# Altium schematic sheet 1.1.1_Interface_board_MCU.SchDoc
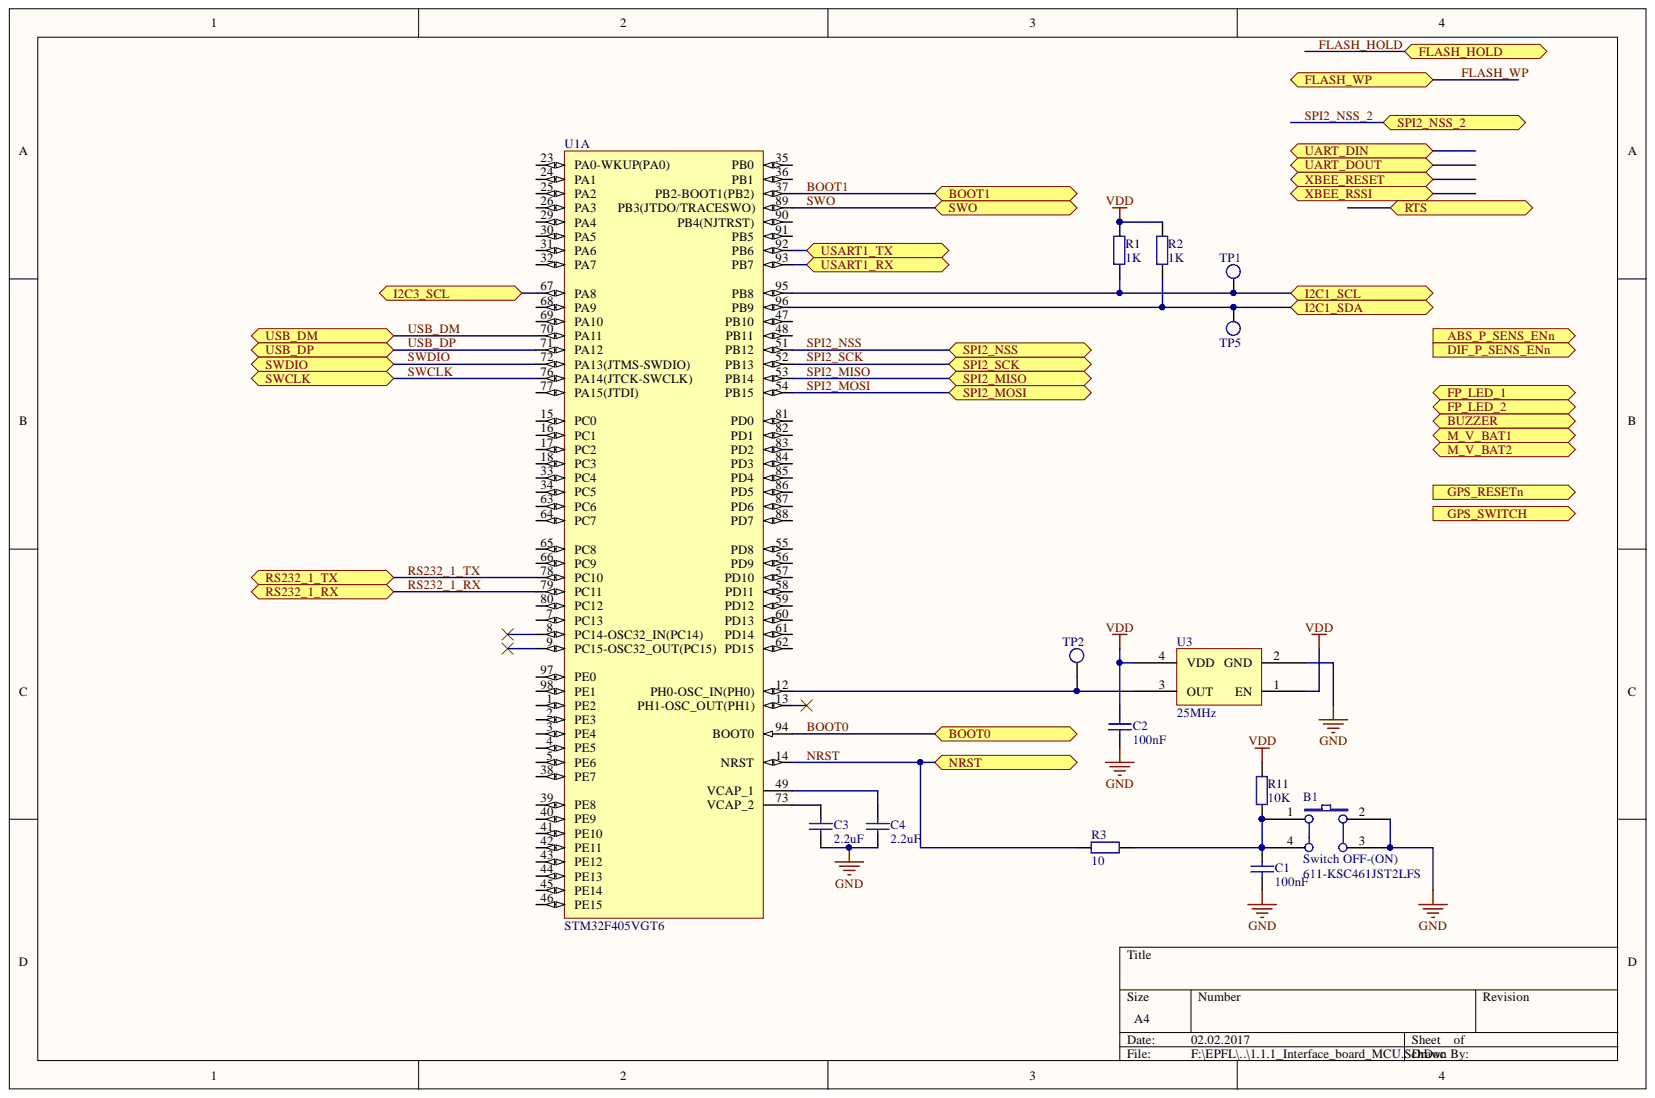
[diagram p0: 1.1.1_Interface_board_MCU.SchDoc]

## Parts
B1  Switch OFF-(ON)  Supplier Part Number 1: 611-KSC461JST2LFS  [Switch CK]
C1  100nF  [CAP0603R]
C2  100nF  [CAP0603R]
C3  2.2uF  [CAP1206W]
C4  2.2uF  [CAP1206W]
R1  1K  [0603]
R2  1K  [0603]
R3  10  [0603]
R11  10K  [0603]
TP1  Test point  [TP]  (value not drawn)
TP2  Test point  [TP]  (value not drawn)
TP5  Test point  [TP]  (value not drawn)
U1  STM32F405VGT6  [STM-LQFP100_N]  (part A)
U3  25MHz  [SMD_3.2X2.5MM_4P]

## Ports
ABS_P_SENS_ENN, BOOT0, BOOT1, BUZZER, DIF_P_SENS_ENN, FLASH_HOLD, FLASH_WP, FP_LED_1, FP_LED_2, GPS_RESETN, GPS_SWITCH, I2C1_SCL, I2C1_SDA, I2C3_SCL, M_V_BAT1, M_V_BAT2, NRST, RS232_1_RX, RS232_1_TX, RTS, SPI2_MISO, SPI2_MOSI, SPI2_NSS, SPI2_NSS_2, SPI2_SCK, SWCLK, SWDIO, SWO, UART_DIN, UART_DOUT, USART1_RX, USART1_TX, USB_DM, USB_DP, XBEE_RESET, XBEE_RSSI

## Nets
GND: B1.2 B1.3 C1.2 C2.1 C3.1 C4.1 U3.2(GND)
VDD: C2.2 R1.2 R2.2 R11.2 U3.1(EN) U3.4(VDD)
BOOT0: U1.94(BOOT0) ; port BOOT0
BOOT1: U1.37(PB2-BOOT1(PB2)) ; port BOOT1
I2C1_SCL: R1.1 TP1.1 U1.95(PB8) ; port I2C1_SCL
I2C1_SDA: R2.1 TP5.1 U1.96(PB9) ; port I2C1_SDA
I2C3_SCL: U1.67(PA8) ; port I2C3_SCL
NRST: R3.1 U1.14(NRST) ; port NRST
RS232_1_RX: U1.79(PC11) ; port RS232_1_RX
RS232_1_TX: U1.78(PC10) ; port RS232_1_TX
SPI2_MISO: U1.53(PB14) ; port SPI2_MISO
SPI2_MOSI: U1.54(PB15) ; port SPI2_MOSI
SPI2_NSS: U1.51(PB12) ; port SPI2_NSS
SPI2_SCK: U1.52(PB13) ; port SPI2_SCK
SWCLK: U1.76(PA14(JTCK-SWCLK)) ; port SWCLK
SWDIO: U1.72(PA13(JTMS-SWDIO)) ; port SWDIO
SWO: U1.89(PB3(JTDO/TRACESWO)) ; port SWO
USART1_RX: U1.93(PB7) ; port USART1_RX
USART1_TX: U1.92(PB6) ; port USART1_TX
USB_DM: U1.70(PA11) ; port USB_DM
USB_DP: U1.71(PA12) ; port USB_DP
NetB1_1: B1.1 B1.4 C1.1 R3.2 R11.1
NetC3_2: C3.2 U1.73(VCAP_2)
NetC4_2: C4.2 U1.49(VCAP_1)
NetTP2_1: TP2.1 U1.12(PH0-OSC_IN(PH0)) U3.3(OUT)
FLASH_HOLD: ; port FLASH_HOLD
FLASH_WP: ; port FLASH_WP
N00006: ; port DIF_P_SENS_ENN
N00007: ; port ABS_P_SENS_ENN
N00008: ; port M_V_BAT1
N00009: ; port GPS_RESETN
N00010: ; port GPS_SWITCH
N00011: ; port M_V_BAT2
N00012: ; port BUZZER
N00013: ; port FP_LED_2
N00014: ; port FP_LED_1
N00015: ; port RTS
N00016: ; port XBEE_RESET
N00017: ; port XBEE_RSSI
N00018: ; port UART_DOUT
N00019: ; port UART_DIN
SPI2_NSS_2: ; port SPI2_NSS_2

Not connected: U1 1(PE2) 2(PE3) 3(PE4) 4(PE5) 5(PE6) 7(PC13) 8(PC14-OSC32_IN(PC14)) 9(PC15-OSC32_OUT(PC15)) 13(PH1-OSC_OUT(PH1)) 15(PC0) 16(PC1) 17(PC2) 18(PC3) 23(PA0-WKUP(PA0)) 24(PA1) 25(PA2) 26(PA3) 29(PA4) 30(PA5) 31(PA6) 32(PA7) 33(PC4) 34(PC5) 35(PB0) 36(PB1) 38(PE7) 39(PE8) 40(PE9) 41(PE10) 42(PE11) 43(PE12) 44(PE13) 45(PE14) 46(PE15) 47(PB10) 48(PB11) 55(PD8) 56(PD9) 57(PD10) 58(PD11) 59(PD12) 60(PD13) 61(PD14) 62(PD15) 63(PC6) 64(PC7) 65(PC8) 66(PC9) 68(PA9) 69(PA10) 77(PA15(JTDI)) 80(PC12) 81(PD0) 82(PD1) 83(PD2) 84(PD3) 85(PD4) 86(PD5) 87(PD6) 88(PD7) 90(PB4(NJTRST)) 91(PB5) 97(PE0) 98(PE1)

## Library data of the parts
B1 = Switch OFF-(ON): OFF-(ON); Component Kind: Standard; Supplier 1: Mouser; Library Name: Rocket_library.SchLib
C1 C2 = C_100nF: Capacitor; Component Kind: Standard; Signal Integrity: Cap; Simulation: CAP; Supplier 1: Mouser; Supplier Part Number 1: 81-GCG188R91H104KA1D; Library Name: Rocket_library.SchLib
C3 C4 = C_2.2uF_1206: Capacitor; Component Kind: Standard; Signal Integrity: Cap; Simulation: CAP; Supplier 1: Mouser; Supplier Part Number 1: 81-GCG31MR71E225JA2L; Library Name: Rocket_library.SchLib
R1 R2 = R_0603_01W_1K: 0.1W 1K; Component Kind: Standard; Signal Integrity: Res; Simulation: RESISTOR; Supplier 1: Mouser; Supplier Part Number 1: 667-ERJ-3GEYJ102V; Library Name: Rocket_library.SchLib
R3 = R_0603_025W_10Ohm: 0.25W 10Ohm; Component Kind: Standard; Supplier 1: Mouser; Supplier Part Number 1: 667-ERJ-PA3J100V; Library Name: Rocket_library.SchLib
R11 = R_0603_01W_10K: 0.1W 10K; Component Kind: Standard; Signal Integrity: Res; Simulation: RESISTOR; Supplier 1: Mouser; Supplier Part Number 1: 667-ERJ-3GEYJ103V; Library Name: Rocket_library.SchLib
U1 = STM32F405VGT6: ARM Cortex-M4 32-bit MCU+FPU, 210 DMIPS, 1024 kB Flash, 192 kB Internal RAM, 82 I/Os, 100-pin LQFP, -40 to 85 degC, Tray; Manufacturer: STMicroelectronics; D/A Converter: 2x12-bit; FLASH Size (Prog) (kB): 1024; I/Os (High Current): 82; Internal RAM Size (kB): 192; Operating Frequency(F) (Processor speed) (MHz): 168; Supply Voltage(Vcc) max (V): 3.6; Supply Voltage(Vcc) min (V): 1.8; Component Kind: Standard; ComponentLink1Description: Manufacturer URL; ComponentLink1URL: http://www.st.com/web/en/home.html; ComponentLink2Description: Datasheet; ComponentLink2URL: http://www.st.com/st-web-ui/static/active/en/resource/technical/document/datasheet/DM00037051.pdf; ComponentLink3Description: Package Specification; ComponentLink3URL: http://www.st.com/st-web-ui/static/active/en/resource/technical/document/data_brief/DM00071938.pdf; Core: ARM Cortex-M4; DatasheetVersion: Rev. 4; Library Name: STMicroelectronics STM32 F4.IntLib; Mounting Technology: Surface Mount; PackageDescription: 100-Pin Low Profile Quad Flat Package, 14 x 14 mm Body, 0.5 mm Pitch; PackageReference: LQFP100; PackageVersion: Rev. 1, 06/2013; Packing: Tray; PartNumber: STM32F405VGT6; Supplier 1: Digi-Key; Supplier 2: Farnell; Supplier 3: Newark; Supplier 4: Newark; Supplier 5: Mouser; Supplier Part Number 1: 497-11910-ND; Supplier Part Number 2: 2215224; Supplier Part Number 3: 46W2199; Supplier Part Number 4: 94T6493; Supplier Part Number 5: 511-STM32F405VGT6
U3 = DSC1001CI2-025.0000: Osc; Component Kind: Standard; Supplier 1: Mouser; Supplier Part Number 1: 998-1001CI2025.0000T; Library Name: Rocket_library.SchLib
TP1 TP2 TP5 = Test point: Component Kind: Standard; Library Name: Rocket_library.SchLib
